# Altium schematic sheet T962a_Panel.SchDoc(T962a_Panel)
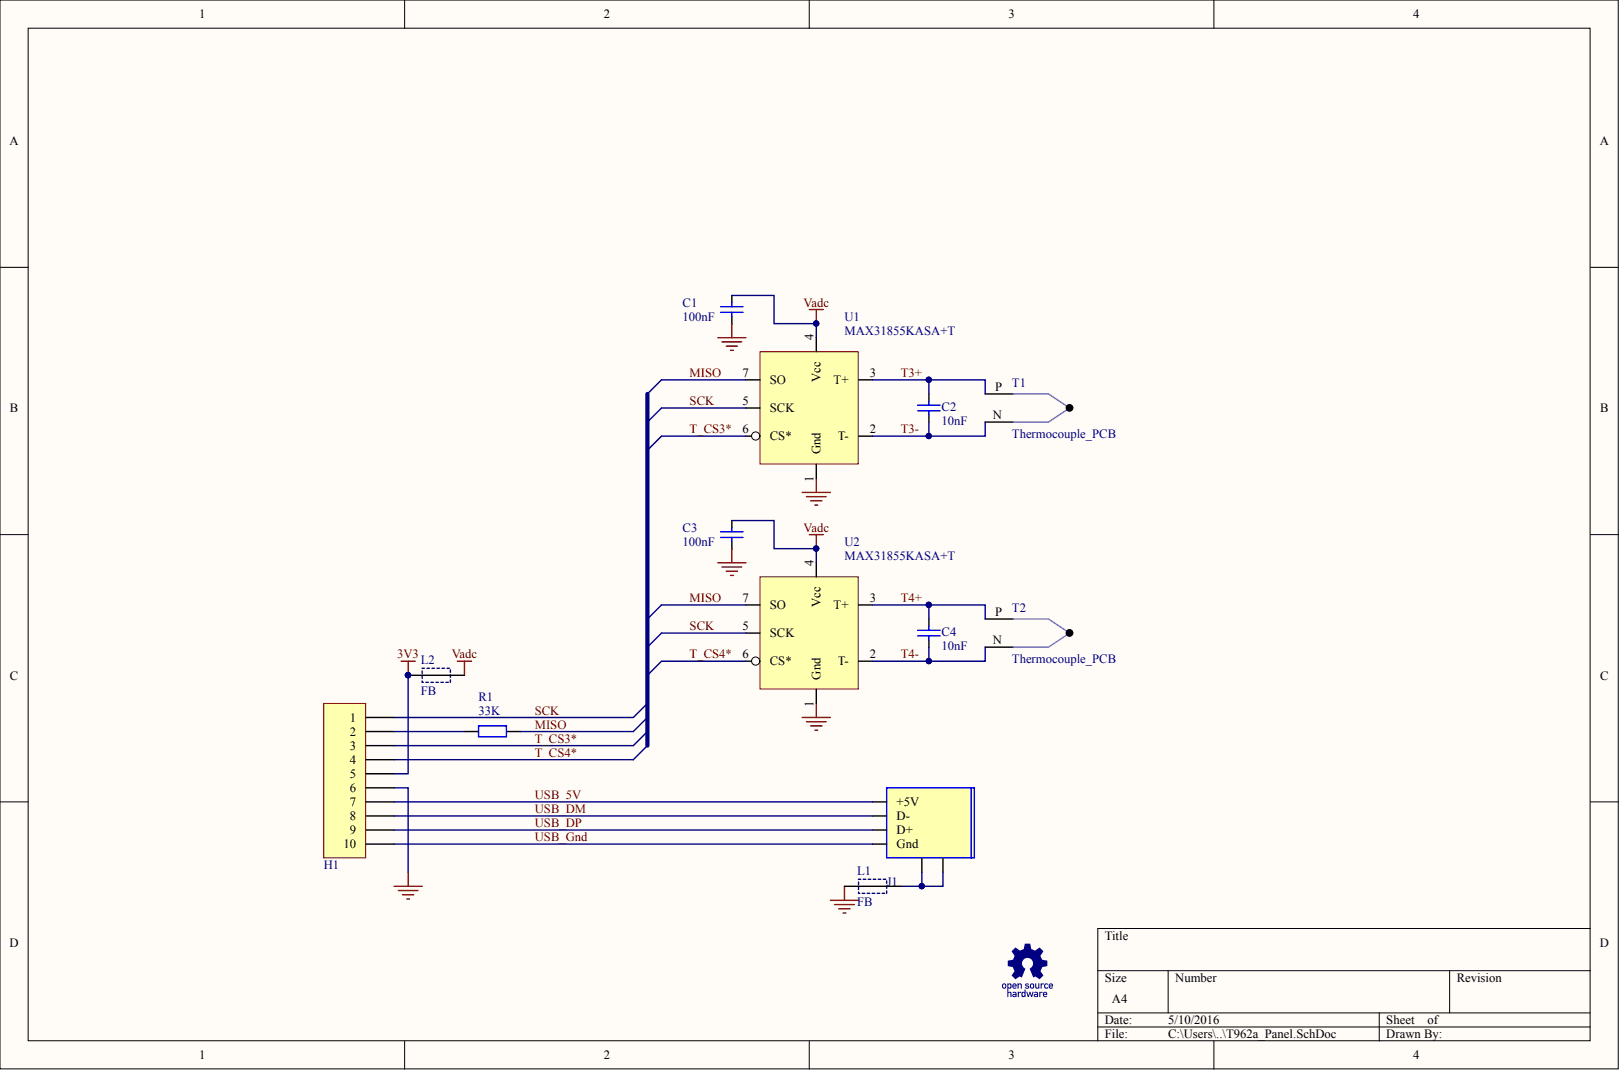
[diagram p0: T962a_Panel.SchDoc]

## Parts
C1  100nF  [CCNP1608-0603]
C2  10nF  [CCNP1608-0603]
C3  100nF  [CCNP1608-0603]
C4  10nF  [CCNP1608-0603]
H1  2x5 Header  [HDR2X5H]  (value not drawn)
J1  USB Type B  [USB_BCon - straight pins]  (value not drawn)
L1  FB  [CR2012-0805]
L2  FB  [CR2012-0805]
Logo  OSHW Logo  [OSHW]  (value not drawn)  (no BOM)
R1  33K  [CR2012-0805]
T1  Thermocouple_PCB  [ThermoCoupleSocket - PCB mount]
T2  Thermocouple_PCB  [ThermoCoupleSocket - PCB mount]
U1  MAX31855KASA+T  [SO-8_L]
U2  MAX31855KASA+T  [SO-8_L]

## Nets
3V3: H1.5 L2.1
GND: C1.1 C3.1 H1.6 L1.1 U1.1(Gnd) U2.1(Gnd)
VADC: C1.2 C3.2 L2.2 U1.4(Vcc) U2.4(Vcc)
MISO: R1.2 U1.7(SO) U2.7(SO)
SCK: H1.1 U1.5(SCK) U2.5(SCK)
T3+: C2.1 T1.P U1.3(T+)
T3-: C2.2 T1.N U1.2(T-)
T4+: C4.1 T2.P U2.3(T+)
T4-: C4.2 T2.N U2.2(T-)
T_CS3*: H1.3 U1.6(CS*)
T_CS4*: H1.4 U2.6(CS*)
USB_5V: H1.7 J1.5V(+5V)
USB_DM: H1.8 J1.D-
USB_DP: H1.9 J1.D+
USB_GND: H1.10 J1.Gnd
NetH1_2: H1.2 R1.1
NetJ1_Shield1: J1.Shield1 J1.Shield2 L1.2
N00006:

## Library data of the parts
C1-C4 = Cap: Capacitor; Manufacturer: Generic Components; Class: Passive; Component Kind: Standard; Library Name: MyLibrary.IntLib; Published: 08/06/2000; Signal Integrity: Cap; Simulation: CAP; SubClass: Capacitor; Publisher: Altium Hobart Technology Centre
  C1 C3: Value: 100nF
  C2 C4: Value: 10nF
H1 = Header 2x5H: Header 2x5; Component Kind: Standard; Library Name: MyLibrary.IntLib
J1 = USB_TYPE_B: USB B Connector; Component Kind: Standard; Library Name: MyLibrary.IntLib
L1 L2 = Ferrite Bead 0805: Ferrite Bead (0805) 0.045OHM, 2A; Component Kind: Standard; Farnell PN: 1515661; Library Name: MyLibrary.IntLib
R1 = Res: Resistor; Manufacturer: Generic Components; Class: Passive; Component Kind: Standard; Library Name: MyLibrary.IntLib; Published: 08/06/2000; Signal Integrity: Res; Simulation: RESISTOR; SubClass: Resistor; Value: 33K; Publisher: Altium Hobart Technology Centre
Logo = OSHW Logo: Library Name: MyLibrary.IntLib
T1 T2 = Thermocouple_PCB: Component Kind: Standard; Farnell PN: 2347189; Library Name: MySchLib.schlib
U1 U2 = MAX31855KASA+T: Component Kind: Standard; Farnell PN: 2515622; Library Name: MySchLib.schlib
